# Altium schematic sheet WM_Display.SchDoc
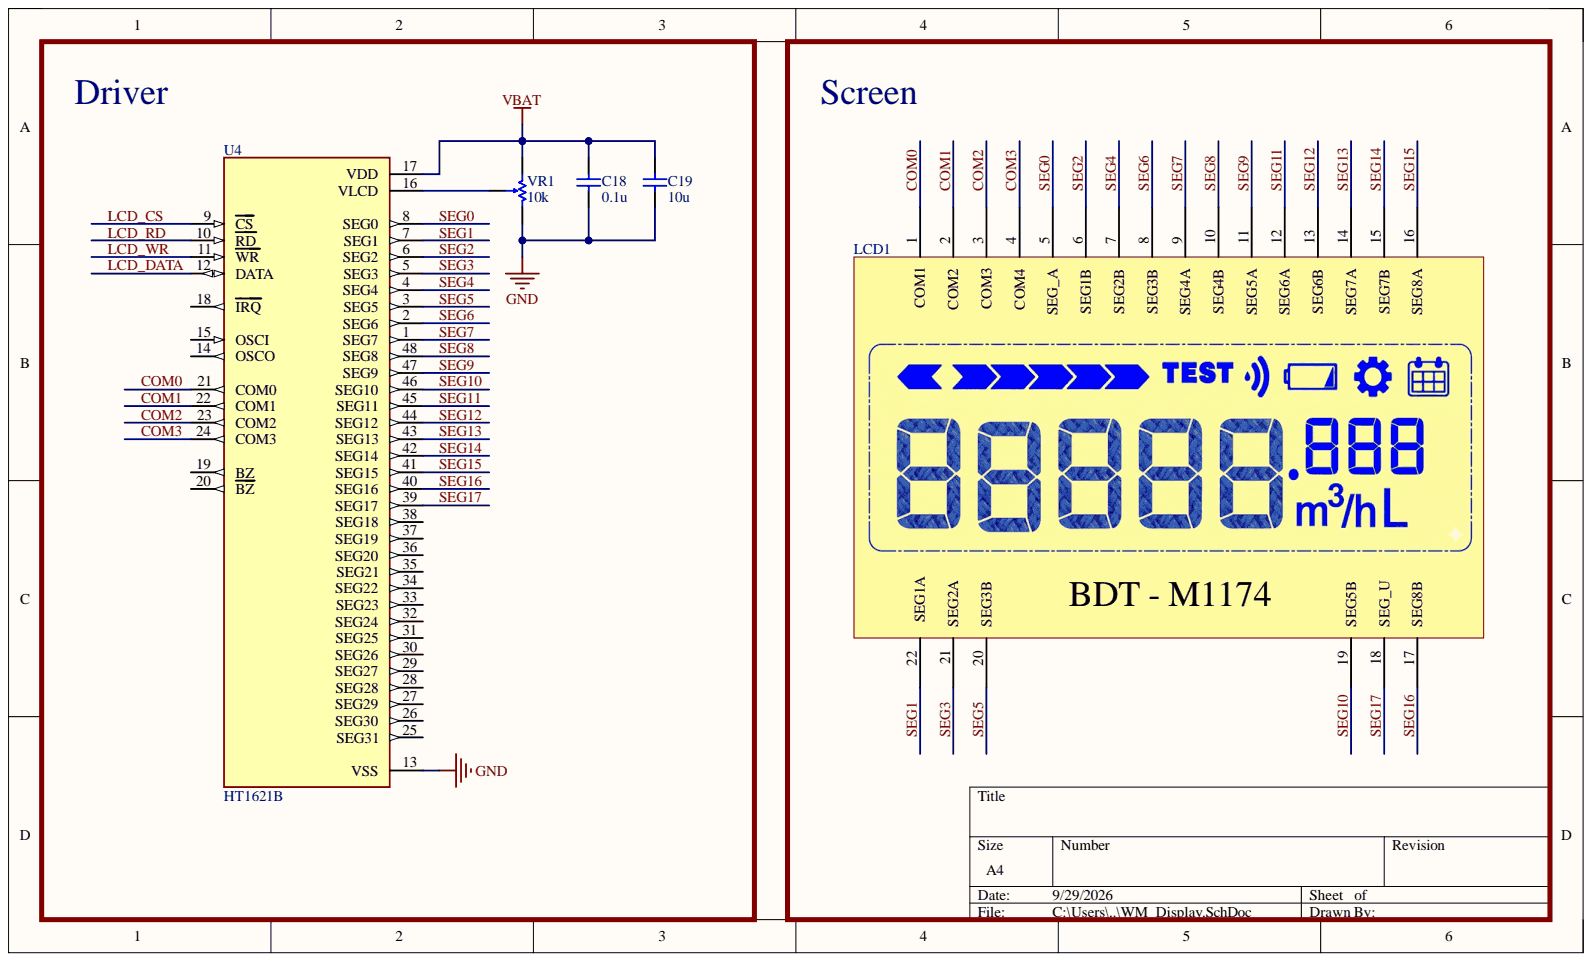
[diagram p0: WM_Display.SchDoc]

## Parts
C18  0.1u  [C 0603]
C19  10u  [C 0603]
LCD1  BDT-M1174  [BDT-M1174]
U4  HT1621B  [SOP63P1035X279-48N]
VR1  10k  [R VAR A 3D]

## Nets
GND: C18.1 C19.2 U4.13(VSS) VR1.3
VBAT: C18.2 C19.1 U4.17(VDD) VR1.1
COM0: LCD1.1(COM1) U4.21(COM0)
COM1: LCD1.2(COM2) U4.22(COM1)
COM2: LCD1.3(COM3) U4.23(COM2)
COM3: LCD1.4(COM4) U4.24(COM3)
LCD_CS: U4.9(CS)
LCD_DATA: U4.12(DATA)
LCD_RD: U4.10(RD)
LCD_WR: U4.11(WR)
SEG0: LCD1.5(SEG_A) U4.8(SEG0)
SEG1: LCD1.22(SEG1A) U4.7(SEG1)
SEG2: LCD1.6(SEG1B) U4.6(SEG2)
SEG3: LCD1.21(SEG2A) U4.5(SEG3)
SEG4: LCD1.7(SEG2B) U4.4(SEG4)
SEG5: LCD1.20(SEG3B) U4.3(SEG5)
SEG6: LCD1.8(SEG3B) U4.2(SEG6)
SEG7: LCD1.9(SEG4A) U4.1(SEG7)
SEG8: LCD1.10(SEG4B) U4.48(SEG8)
SEG9: LCD1.11(SEG5A) U4.47(SEG9)
SEG10: LCD1.19(SEG5B) U4.46(SEG10)
SEG11: LCD1.12(SEG6A) U4.45(SEG11)
SEG12: LCD1.13(SEG6B) U4.44(SEG12)
SEG13: LCD1.14(SEG7A) U4.43(SEG13)
SEG14: LCD1.15(SEG7B) U4.42(SEG14)
SEG15: LCD1.16(SEG8A) U4.41(SEG15)
SEG16: LCD1.17(SEG8B) U4.40(SEG16)
SEG17: LCD1.18(SEG_U) U4.39(SEG17)
NetU4_16: U4.16(VLCD) VR1.2

Not connected: U4 14(OSCO) 15(OSCI) 18(IRQ) 19(BZ) 20(BZ) 25(SEG31) 26(SEG30) 27(SEG29) 28(SEG28) 29(SEG27) 30(SEG26) 31(SEG25) 32(SEG24) 33(SEG23) 34(SEG22) 35(SEG21) 36(SEG20) 37(SEG19) 38(SEG18)

## Library data of the parts
C18 = Capacitor NP: Ceramic capacitor; Component Kind: Standard; Library Name: chipfc_altium_libs.IntLib
C19 = Capacitor NP: Capacitor non-pol; Component Kind: Standard; Library Name: chipfc_altium_libs.IntLib
LCD1 = BDT-M1174: LCD segment 4 x 18 for water meters; Component Kind: Standard; Library Name: LCD.IntLib
U4 = HT1621B: 128 pattern (32x4), memory mapping, and multi-function LCD driver. The S/W configuration feature of the HT1621makes it suitable for multiple LCD applications including LCD modules and display sub-systems.; MF: Holtek; Check_prices: https://www.snapeda.com/parts/HT1621B/Holtek/view-part/?ref=eda; Component Kind: Standard; Library Name: HT1621B.IntLib; MP: HT1621B; Package: SSOP-48 Holtek; SnapEDA_Link: https://www.snapeda.com/parts/HT1621B/Holtek/view-part/?ref=snap
VR1 = Resistor Variable: Resistor Variable 3362P; Component Kind: Standard; Library Name: chipfc_altium_libs.IntLib
